# Altium schematic sheet Microcontroller.SchDoc(Microcontroller)
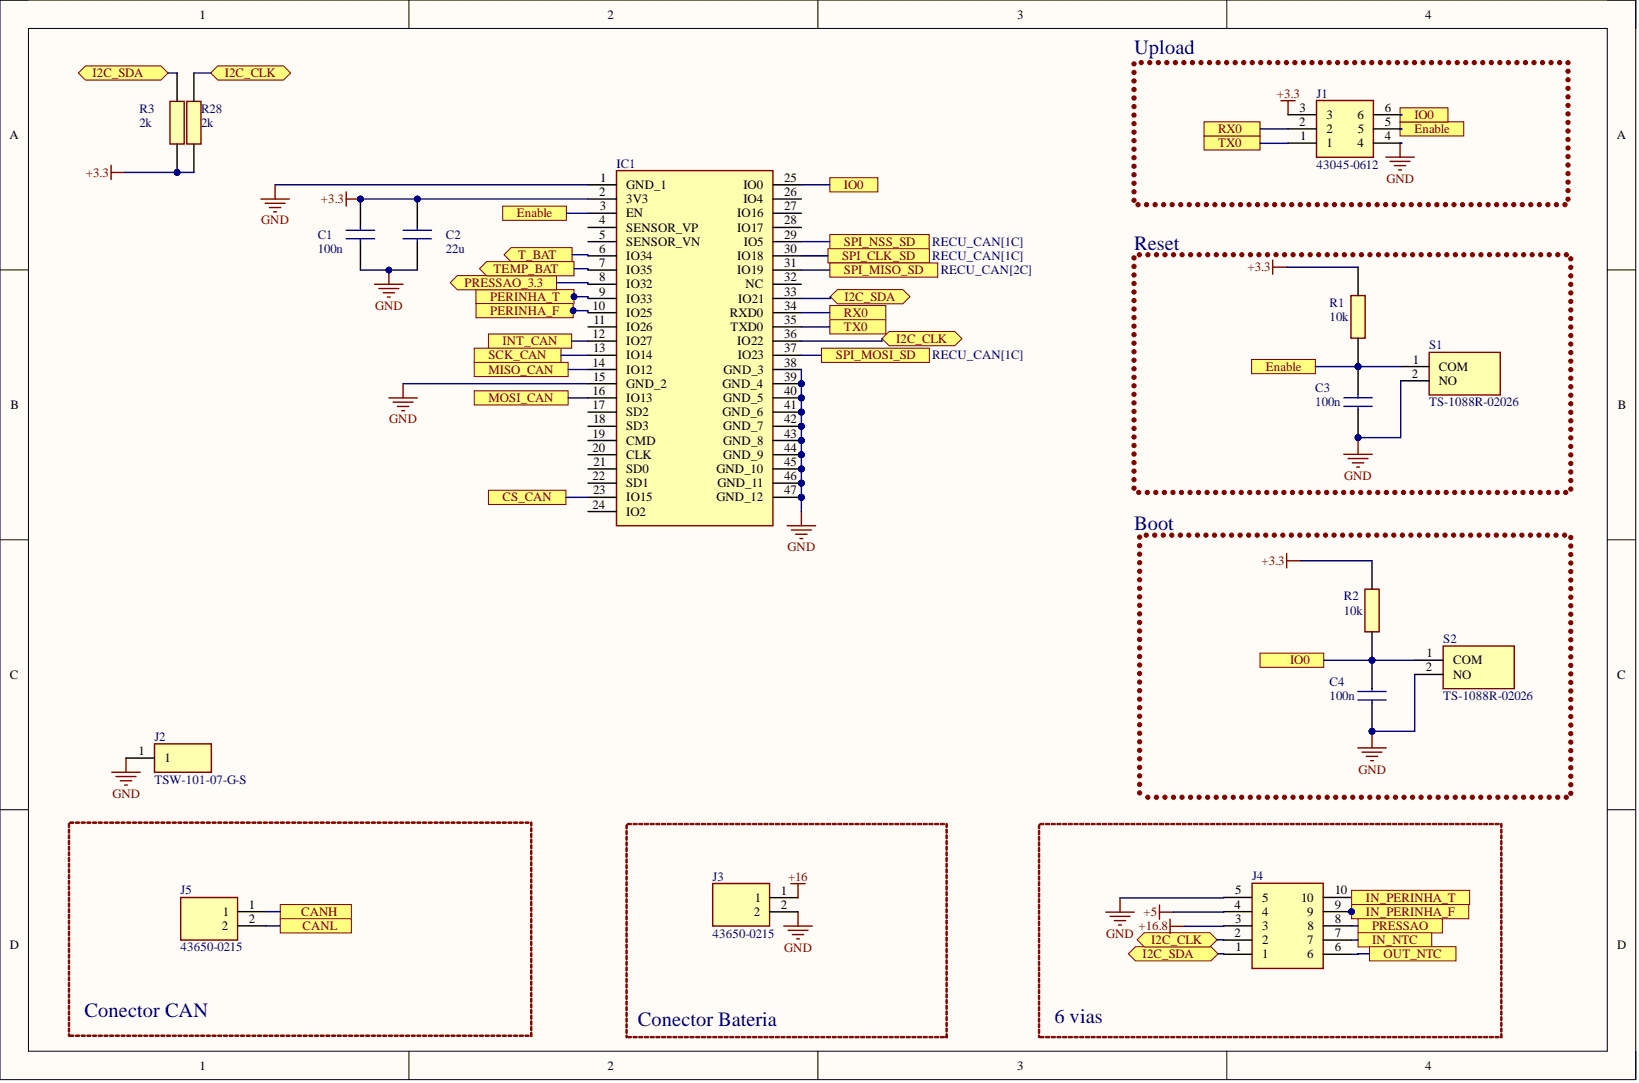
[diagram p0: Microcontroller.SchDoc]

## Parts
C1  22u
C2  100n
C3  100n
C4  100n
IC1  ESP32-WROOM-32_M103QH2800PH3Q0_  (value not drawn)
J1  43045-0612
J2  TSW-101-07-G-S
J3  43650-0215
J4  43045-1012  (value not drawn)
J5  43650-0215
R1  10k
R2  10k
R3  2k
R28  2k
S1  TS-1088R-02026
S2  TS-1088R-02026

## Ports
CANH, CANL, CS_CAN, Enable, I2C_CLK, I2C_SDA, IN_NTC, IN_PERINHA_F, IN_PERINHA_T, INT_CAN, IO0, MISO_CAN, MOSI_CAN, OUT_NTC, PERINHA_F, PERINHA_T, PRESSAO, PRESSAO_3.3, RX0, SCK_CAN, SPI_CLK_SD, SPI_MISO_SD, SPI_MOSI_SD, SPI_NSS_SD, T_BAT, TEMP_BAT, TX0

## Nets
+3.3: C1.2 C2.1 IC1.2(3V3) J1.3 R1.1 R2.1 R3.1 R28.1
+5: J4.4
+16: J3.1
+16.8: J4.3
GND: C1.1 C2.2 C3.1 C4.1 IC1.1(GND_1) IC1.15(GND_2) IC1.38(GND_3) IC1.39(GND_4) IC1.40(GND_5) IC1.41(GND_6) IC1.42(GND_7) IC1.43(GND_8) IC1.44(GND_9) IC1.45(GND_10) IC1.46(GND_11) IC1.47(GND_12) J1.4 J2.1 J3.2 J4.5 S1.2 S2.2
CANH: J5.1 ; port CANH
CANL: J5.2 ; port CANL
CS_CAN: IC1.23(IO15) ; port CS_CAN
Enable: C3.2 IC1.3(EN) J1.5 R1.2 S1.1 ; port Enable
I2C_CLK: IC1.36(IO22) J4.2 R28.2 ; port I2C_CLK
I2C_SDA: IC1.33(IO21) J4.1 R3.2 ; port I2C_SDA
IN_NTC: J4.7 ; port IN_NTC
IN_PERINHA_F: J4.9 ; port IN_PERINHA_F
IN_PERINHA_T: J4.10 ; port IN_PERINHA_T
INT_CAN: IC1.12(IO27) ; port INT_CAN
IO0: C4.2 IC1.25(IO0) J1.6 R2.2 S2.1 ; port IO0
MISO_CAN: IC1.14(IO12) ; port MISO_CAN
MOSI_CAN: IC1.16(IO13) ; port MOSI_CAN
OUT_NTC: J4.6 ; port OUT_NTC
PERINHA_F: IC1.10(IO25) ; port PERINHA_F
PERINHA_T: IC1.9(IO33) ; port PERINHA_T
PRESSAO: J4.8 ; port PRESSAO
PRESSAO_3.3: IC1.8(IO32) ; port PRESSAO_3.3
RX0: IC1.34(RXD0) J1.2 ; port RX0
SCK_CAN: IC1.13(IO14) ; port SCK_CAN
SPI_CLK_SD: IC1.30(IO18) ; port SPI_CLK_SD
SPI_MISO_SD: IC1.31(IO19) ; port SPI_MISO_SD
SPI_MOSI_SD: IC1.37(IO23) ; port SPI_MOSI_SD
SPI_NSS_SD: IC1.29(IO5) ; port SPI_NSS_SD
T_BAT: IC1.6(IO34) ; port T_BAT
TEMP_BAT: IC1.7(IO35) ; port TEMP_BAT
TX0: IC1.35(TXD0) J1.1 ; port TX0

Not connected: IC1 4(SENSOR_VP) 5(SENSOR_VN) 11(IO26) 17(SD2) 18(SD3) 19(CMD) 20(CLK) 21(SD0) 22(SD1) 24(IO2) 26(IO4) 27(IO16) 28(IO17) 32(NC)

## Library data of the parts
C1 = CL21A226MAYNNNE: Capacitor; Manufacturer_Name: SAMSUNG; Arrow Part Number: CL21A226MAYNNNE; Arrow Price/Stock: https://www.arrow.com/en/products/cl21a226maynnne/samsung-electro-mechanics?utm_currency=USD&region=europe; Component Kind: Standard; Datasheet Link: http://product.samsungsem.com/mlcc/CL21A226MAYNNN.do; Height: 1.45mm; Manufacturer_Part_Number: CL21A226MAYNNNE; Mouser Part Number: 187-CL21A226MAYNNNE; Mouser Price/Stock: https://www.mouser.co.uk/ProductDetail/Samsung-Electro-Mechanics/CL21A226MAYNNNE?qs=xZ%2FP%252Ba9zWqY%2FVvCfXjMhqg%3D%3D
C2-C4 = CL21B104KBCNNNC: Capacitor; Manufacturer_Name: SAMSUNG; Arrow Part Number: CL21B104KBCNNNC; Arrow Price/Stock: https://www.arrow.com/en/products/cl21b104kbcnnnc/samsung-electro-mechanics?region=europe; Component Kind: Standard; Datasheet Link: https://datasheet.datasheetarchive.com/originals/distributors/Datasheets_SAMA/86a3eaf0882763ef32124d5901f9b833.pdf; Height: 0.95mm; Manufacturer_Part_Number: CL21B104KBCNNNC; Mouser Part Number: 187-CL21B104KBCNNNC; Mouser Price/Stock: https://www.mouser.co.uk/ProductDetail/Samsung-Electro-Mechanics/CL21B104KBCNNNC?qs=349EhDEZ59pEfpnm5yBEmQ%3D%3D
IC1 = ESP32-WROOM-32_M103QH2800PH3Q0_: Integrated Circuit; Manufacturer_Name: Espressif Systems; Manufacturer_Part_Number: ESP32-WROOM-32(M103QH2800PH3Q0); Component Kind: Standard; Datasheet Link: https://th.mouser.com/datasheet/2/891/esp32-wroom-32_datasheet_en-1510934.pdf; Height: 3.2mm; Library Name: SamacSys.SchLib; Mouser Part Number: 356-ESPWROOM3216MB; Mouser Price/Stock: https://www.mouser.co.uk/ProductDetail/Espressif-Systems/ESP32-WROOM-32M103QH2800PH3Q0?qs=W%2FMpXkg%252BdQ7IcgHFTTq3Ig%3D%3D
J1 = 43045-0612: Connector; Manufacturer_Name: Molex; Arrow Part Number: 43045-0612; Arrow Price/Stock: https://www.arrow.com/en/products/43045-0612/molex?region=nac; Component Kind: Standard; Datasheet Link: https://www.molex.com/pdm_docs/sd/430450612_sd.pdf; Height: 9.91mm; Library Name: SamacSys.SchLib; Manufacturer_Part_Number: 43045-0612; Mouser Part Number: 538-43045-0612; Mouser Price/Stock: https://www.mouser.co.uk/ProductDetail/Molex/43045-0612?qs=KC2ywxza1kqWo9LLJ5Lh3w%3D%3D
J2 = TSW-101-07-G-S: Connector; Manufacturer_Name: SAMTEC; Arrow Part Number: TSW-101-07-G-S; Arrow Price/Stock: https://www.arrow.com/en/products/tsw-101-07-g-s/samtec?region=europe; Component Kind: Standard; Datasheet Link: http://suddendocs.samtec.com/prints/tsw-xxx-xx-xxx-x-xx-xxx-mkt.pdf; Manufacturer_Part_Number: TSW-101-07-G-S; Mouser Part Number: 200-TSW10107GS; Mouser Price/Stock: https://www.mouser.co.uk/ProductDetail/Samtec/TSW-101-07-G-S?qs=hzq9O0YpnZscfPe5oPvABA%3D%3D
J3 J5 = 43650-0215: Connector; Manufacturer_Name: Molex; Component Kind: Standard; Datasheet Link: https://www.molex.com/pdm_docs/sd/436500215_sd.pdf; Height: 9.9mm; Library Name: SamacSys.SchLib; Manufacturer_Part_Number: 43650-0215; Mouser Part Number: 538-43650-0215; Mouser Price/Stock: https://www.mouser.co.uk/ProductDetail/Molex/43650-0215?qs=AplfTeSvkkDe0NAwMnlPNw%3D%3D
J4 = 43045-1012: Connector; Manufacturer_Name: Molex; Component Kind: Standard; Datasheet Link: https://www.molex.com/pdm_docs/sd/430450612_sd.pdf; Height: 9.91mm; Manufacturer_Part_Number: 43045-1012; Mouser Part Number: 538-43045-1012; Mouser Price/Stock: https://www.mouser.co.uk/ProductDetail/Molex/43045-1012?qs=KC2ywxza1koTZPAgAiHw3w%3D%3D
R1 R2 = RC0805FR-0710KL: Resistor; Manufacturer_Name: YAGEO; Arrow Part Number: RC0805FR-0710KL; Arrow Price/Stock: https://www.arrow.com/en/products/rc0805fr-0710kl/yageo?utm_currency=USD&region=europe; Component Kind: Standard; Datasheet Link: https://www.yageo.com/upload/media/product/productsearch/datasheet/rchip/PYu-RC_Group_51_RoHS_L_11.pdf; Height: 0.6mm; Manufacturer_Part_Number: RC0805FR-0710KL; Mouser Part Number: 603-RC0805FR-0710KL; Mouser Price/Stock: https://www.mouser.co.uk/ProductDetail/YAGEO/RC0805FR-0710KL?qs=7bY8808IzHq6vrU3BeiiYw%3D%3D
R3 R28 = ERA-6APB202V: Resistor; Manufacturer_Name: Panasonic; Component Kind: Standard; Datasheet Link: https://industrial.panasonic.com/cdbs/www-data/pdf/RDM0000/AOA0000C307.pdf; Height: 0.6mm; Library Name: SamacSys.SchLib; Manufacturer_Part_Number: ERA-6APB202V; Mouser Part Number: 667-ERA-6APB202V; Mouser Price/Stock: https://www.mouser.co.uk/ProductDetail/Panasonic/ERA-6APB202V?qs=ob%252BdNz2%252BYEg%252BI4Q3Z4g4FA%3D%3D
S1 S2 = TS-1088R-02026: Switch; Manufacturer_Name: XUNPU; Component Kind: Standard; Datasheet Link: https://datasheet.lcsc.com/szlcsc/1912111437_XUNPU-TS-1088R-02026_C455280.pdf; Manufacturer_Part_Number: TS-1088R-02026
